# Altium schematic sheet [1]_Power Supply.SchDoc("[1]_Power Supply")
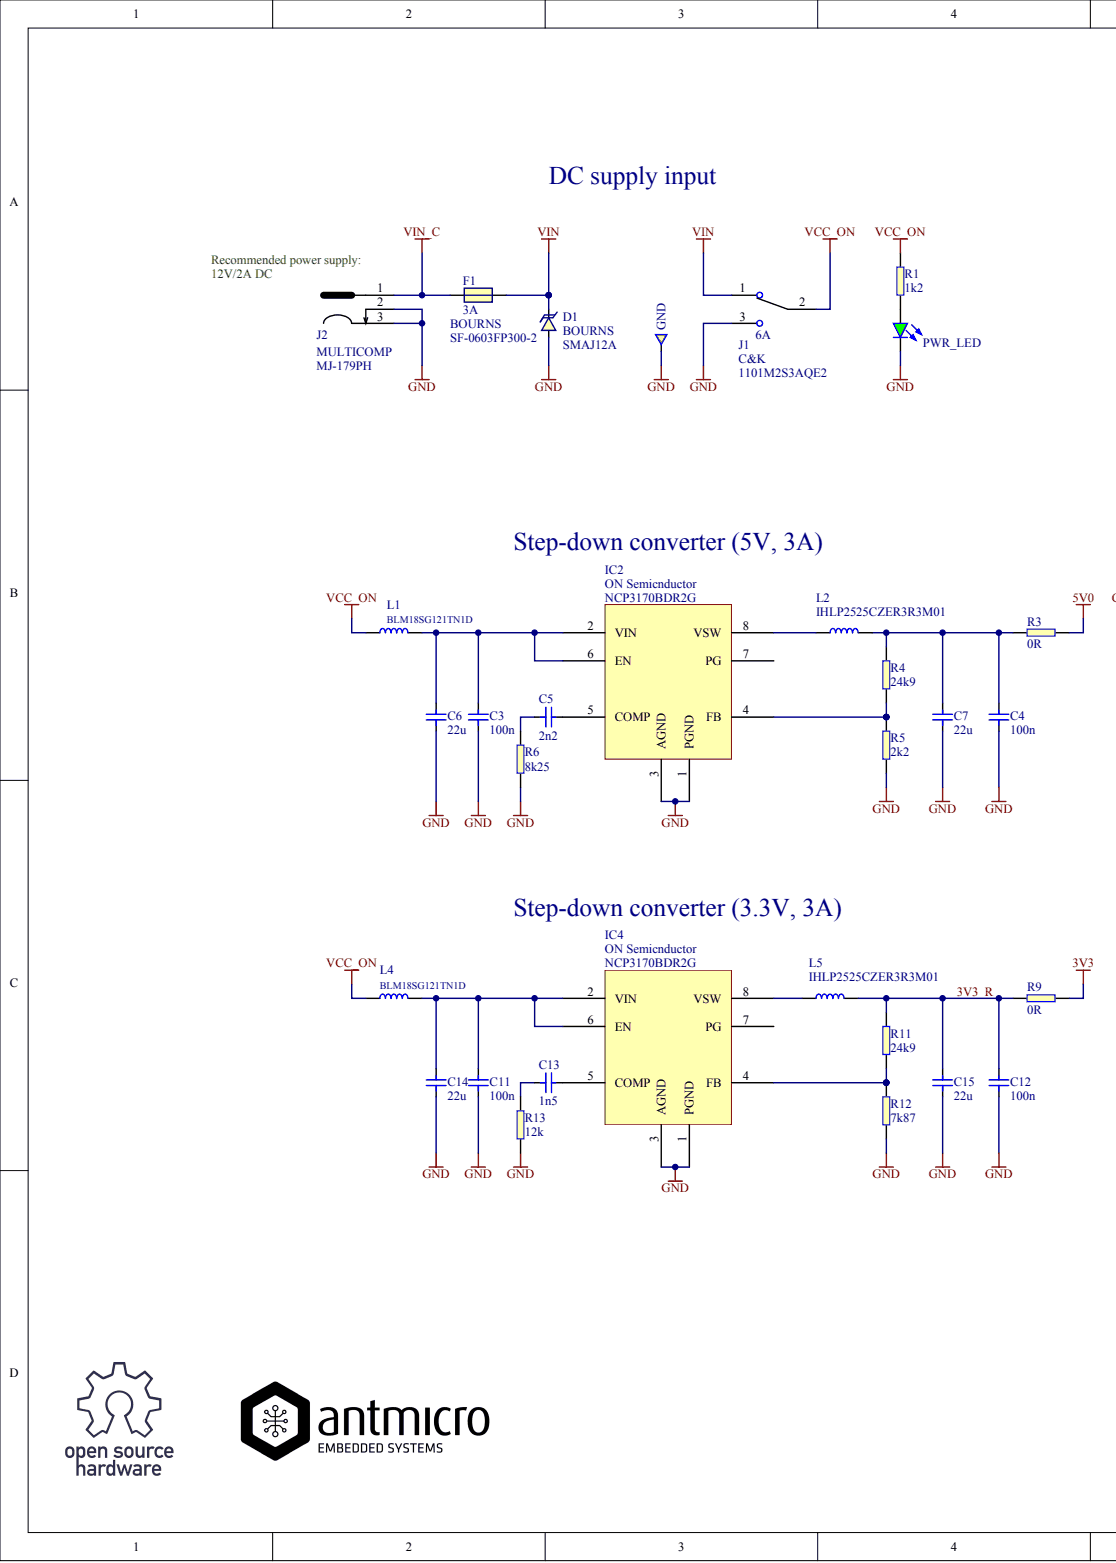
[diagram p0: [1]_Power Supply.SchDoc, left]
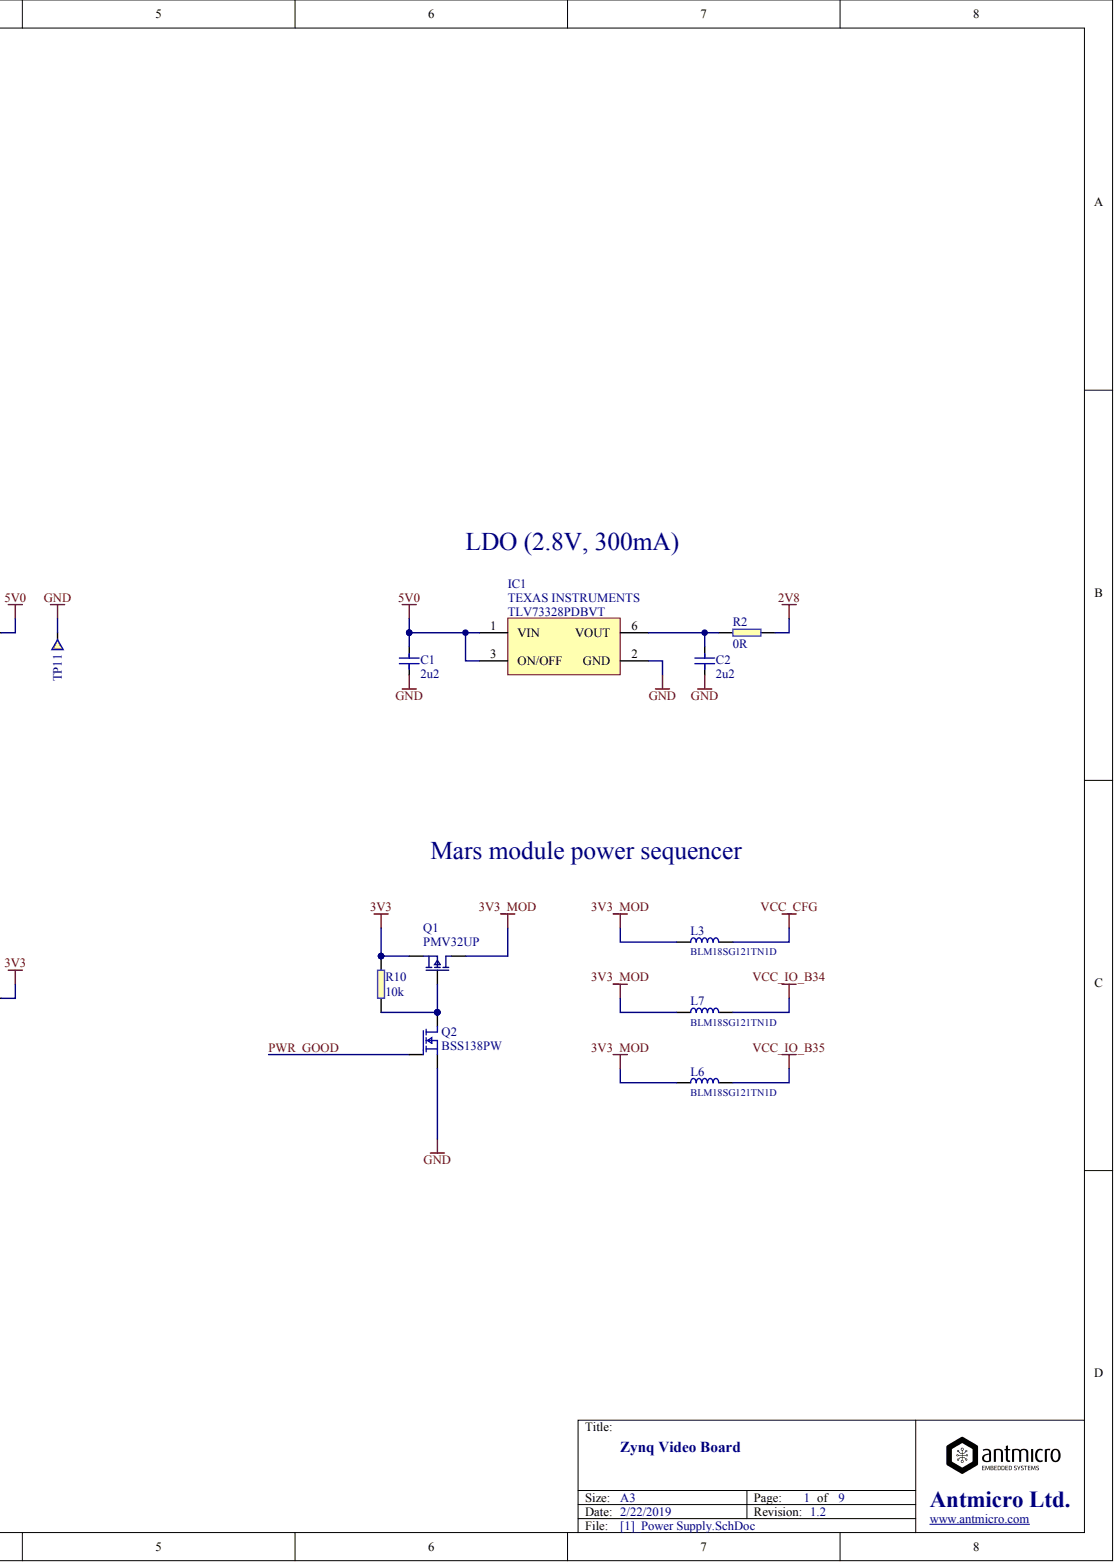
[diagram p1: [1]_Power Supply.SchDoc, right]

## Parts
C1  2u2
C2  2u2
C3  100n
C4  100n
C5  2n2
C6  22u
C7  22u
C11  100n
C12  100n
C13  1n5
C14  22u
C15  22u
D1  Manufacturer: BOURNS  (hidden comment: SMAJ12A)
F1  Manufacturer: BOURNS  (hidden comment: 3A)
GND  Testpad  (value not drawn)
IC1  Manufacturer: TEXAS INSTRUMENTS  (hidden comment: TLV73328PDBVT)
IC2  Manufacturer: ON Semicnductor  (hidden comment: NCP3170BDR2G)
IC4  Manufacturer: ON Semicnductor  (hidden comment: NCP3170BDR2G)
J1  Manufacturer: C&K  (hidden comment: 6A)
J2  Manufacturer: MULTICOMP
L1  BLM18SG121TN1D
L2  IHLP2525CZER3R3M01
L3  BLM18SG121TN1D
L4  BLM18SG121TN1D
L5  IHLP2525CZER3R3M01
L6  BLM18SG121TN1D
L7  BLM18SG121TN1D
PWR_LED  KPT-1608SGC  (value not drawn)
Q1  PMV32UP
Q2  BSS138PW
R1  1k2
R2  0R
R3  0R
R4  24k9
R5  2k2
R6  8k25
R9  0R
R10  10k
R11  24k9
R12  7k87
R13  12k
TP11  Testpad  (value not drawn)

## Nets
2V8: R2.2
3V3: Q1.2 R9.2 R10.2
3V3_MOD: L3.1 L6.1 L7.1 Q1.3
5V0: C1.2 IC1.1(VIN) IC1.3(ON/OFF) R3.2
GND: C1.1 C2.1 C3.1 C4.1 C6.1 C7.1 C11.1 C12.1 C14.1 C15.1 D1.A GND.1 IC1.2(GND) IC2.1(PGND) IC2.3(AGND) IC4.1(PGND) IC4.3(AGND) J1.3 J2.2 J2.3 PWR_LED.K Q2.2 R5.1 R6.1 R12.1 R13.1 TP11.1
VCC_CFG: L3.2
VCC_IO_B34: L7.2
VCC_IO_B35: L6.2
VCC_ON: J1.2 L1.1 L4.1 R1.2
VIN: D1.C F1.2 J1.1
VIN_C: F1.1 J2.1
3V3_R: C12.2 C15.2 L5.2 R9.1 R11.2
PWR_GOOD: Q2.1
NetC2_2: C2.2 IC1.6(VOUT) R2.1
NetC3_2: C3.2 C6.2 IC2.2(VIN) IC2.6(EN) L1.2
NetC4_2: C4.2 C7.2 L2.2 R3.1 R4.2
NetC5_1: C5.1 R6.2
NetC5_2: C5.2 IC2.5(COMP)
NetC11_2: C11.2 C14.2 IC4.2(VIN) IC4.6(EN) L4.2
NetC13_1: C13.1 R13.2
NetC13_2: C13.2 IC4.5(COMP)
NetIC2_4: IC2.4(FB) R4.1 R5.2
NetIC2_8: IC2.8(VSW) L2.1
NetIC4_4: IC4.4(FB) R11.1 R12.2
NetIC4_8: IC4.8(VSW) L5.1
NetPWR_LED_A: PWR_LED.A R1.1
NetQ1_1: Q1.1 Q2.3 R10.1

Not connected: IC2 7(PG); IC4 7(PG)

## Library data of the parts
C1 C2 = (no symbol name): Part Number: C_2u2_0603; Manufacturer: TDK; Part Symbol: C1608X5R1V225K080AC; Voltage: 35V; Tolerance: +-10%; Value: 2u2
C3 C4 C11 C12 = (no symbol name): Part Number: C_100n_0402; Manufacturer: MULTICOMP; Part Symbol: MC0402X104M100CT; Voltage: 10V; Tolerance: +-20%; Value: 100n
C5 = (no symbol name): Part Number: C_2n2_0402; Manufacturer: KEMET; Part Symbol: C0402C222J3GACTU; Voltage: 25V; Tolerance: +-5%; Value: 2n2
C6 C7 C14 C15 = (no symbol name): Part Number: C_22u_0805; Manufacturer: MULTICOMP; Part Symbol: MC0805X226M6R3CT; Voltage: 6.3V; Tolerance: +-20%; Value: 22u
C13 = (no symbol name): Part Number: C_1n5_0402; Manufacturer: WURTH ELEKTRONIK; Part Symbol: 885012205007; Voltage: 10V; Tolerance: +-10%; Value: 1n5
F1 = (no symbol name): Part Number: SF-0603FP300-2; Value: 3A; Part Symbol: SF-0603FP300-2
GND TP11 = (no symbol name): Part Number: TP-R-2
J1 = (no symbol name): Part Number: SPDT Switch; Part Symbol: 1101M2S3AQE2; Value: 6A
J2 = (no symbol name): Part Number: DC_SOCKET; Part Symbol: MJ-179PH; Supplier Part Number 1: 1737246; Supplier 1: Farnell
L1 L3 L4 L6 L7 = (no symbol name): Manufacturer: MURATA; Rated Current: 3A; Part Number: BLM18SG121TN1D; Value: BLM18SG121TN1D; Part Symbol: BLM18SG121TN1D
L2 L5 = (no symbol name): Manufacturer: VISHAY; Rated Current: 6A; Inductance: 3.3uH; Part Number: IHLP2525CZER3R3M01; Value: IHLP2525CZER3R3M01; Part Symbol: IHLP2525CZER3R3M01
PWR_LED = (no symbol name): Part Number: LED_G; Manufacturer: KINGBRIGHT; Value: KPT-1608SGC; Part Symbol: KPT-1608SGC
Q1 Q2 = (no symbol name): Manufacturer: NXP
  Q1: Part Number: PMV32UP; Value: PMV32UP; Part Symbol: PMV32UP
  Q2: Value: BSS138PW; Part Number: BSS138PW; Part Symbol: BSS138PW
R1 = (no symbol name): Part Number: R_1k2_0402; Manufacturer: PANASONIC; Part Symbol: ERJ2RKF1201X; Tolerance: 1%; Value: 1k2
R2 R3 R9 = (no symbol name): Part Number: R_0R_0603; Manufacturer: BOURNS; Part Symbol: CR0603-J/-000ELF; Tolerance: 5%; Value: 0R
R4 R11 = (no symbol name): Part Number: R_24k9_0402; Manufacturer: WALSIN; Part Symbol: WR04X2492FTL; Tolerance: 1%; Value: 24k9
R5 = (no symbol name): Part Number: R_4k7_0402; Manufacturer: PANASONIC ELECTRONIC COMPONENTS; Part Symbol: ERJ2GEJ472X; Tolerance: 5%; Value: 2k2
R6 = (no symbol name): Part Number: R_8k25_0402; Manufacturer: PANASONIC; Part Symbol: ERJ2RKF8251X; Tolerance: 1%; Value: 8k25
R10 = (no symbol name): Part Number: R_10k_0402; Manufacturer: MULTICOMP; Part Symbol: MCMR04X1002FTL; Tolerance: 1%; Value: 10k
R12 = (no symbol name): Part Number: R_7k87_0402; Manufacturer: MULTICOMP; Part Symbol: MCMR04X7871FTL; Tolerance: 1%; Value: 7k87
R13 = (no symbol name): Part Number: R_12k_0402; Manufacturer: VISHAY; Part Symbol: CRCW040212K0FKEDHP; Tolerance: 1%; Value: 12k
D1 IC1 IC2 IC4 = (no symbol name)
  D1: Part Number: SMAJ12A; Value: SMAJ12A; Part Symbol: SMAJ12A
  IC1: Part Number: TLV73328PDBVT; Value: TLV73328PDBVT; Part Symbol: TLV73328PDBVT
  IC2 IC4: Part Number: NCP3170BDR2G; Value: NCP3170BDR2G; Part Symbol: NCP3170BDR2G
